# Altium schematic sheet JB LED DRIVE002.SchDoc
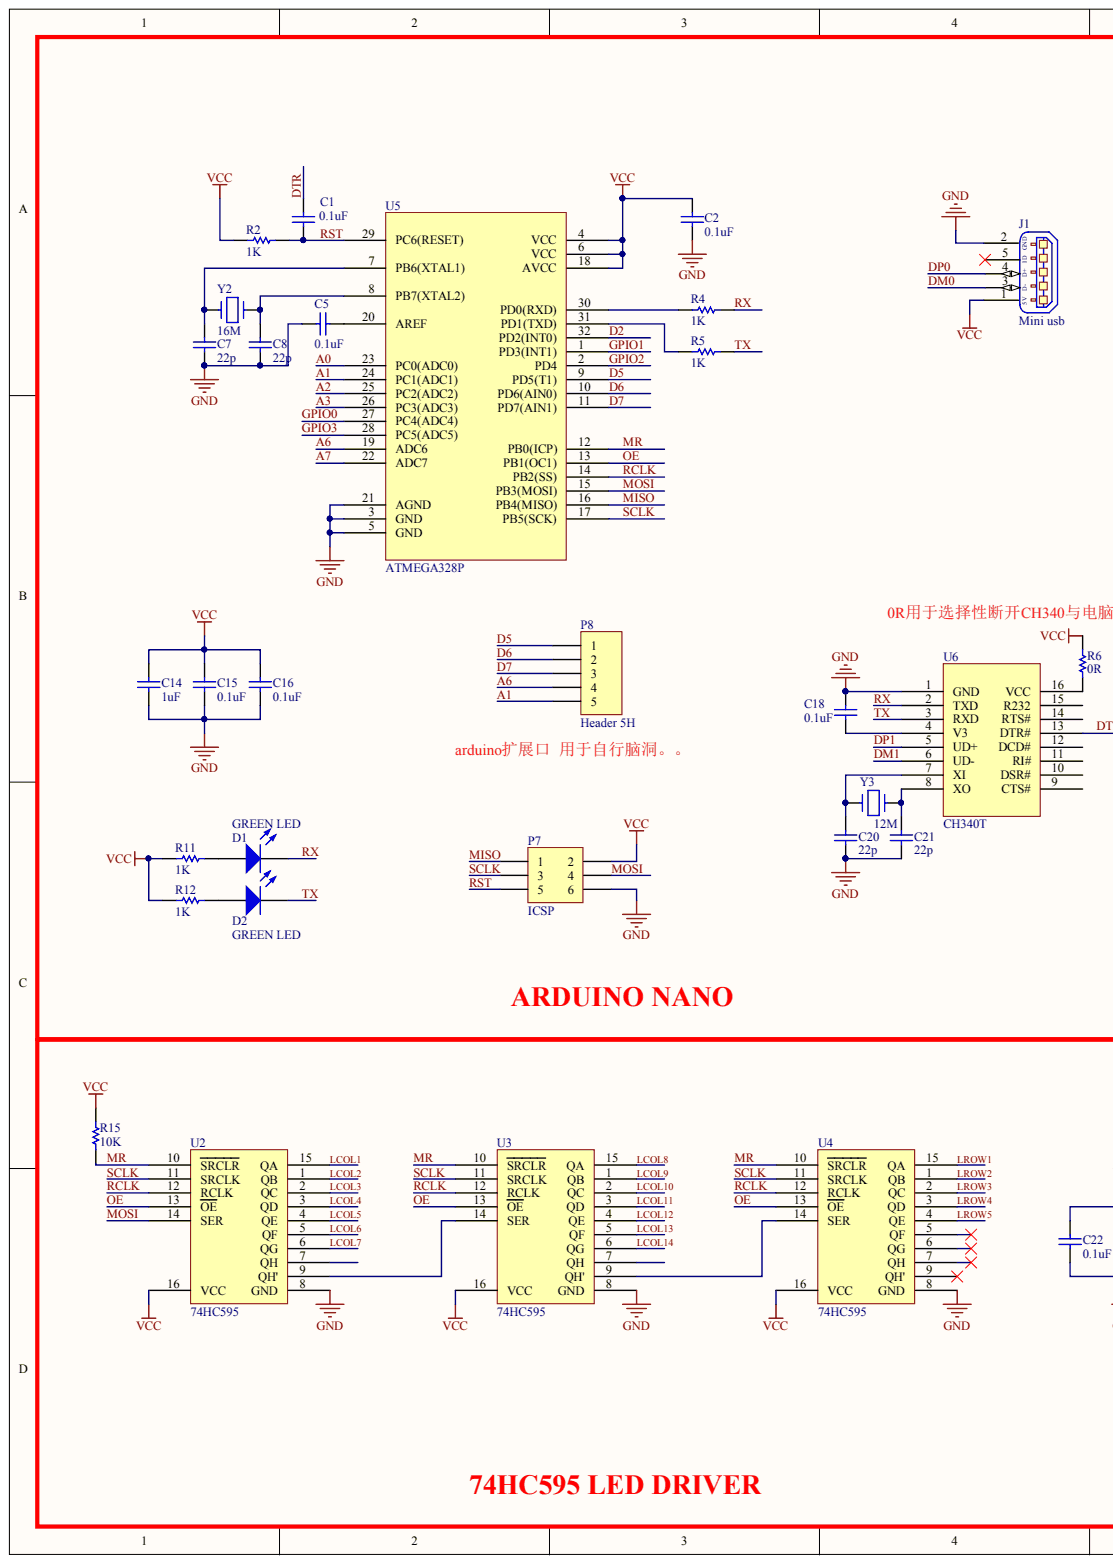
[diagram p0: JB LED DRIVE002.SchDoc, left]
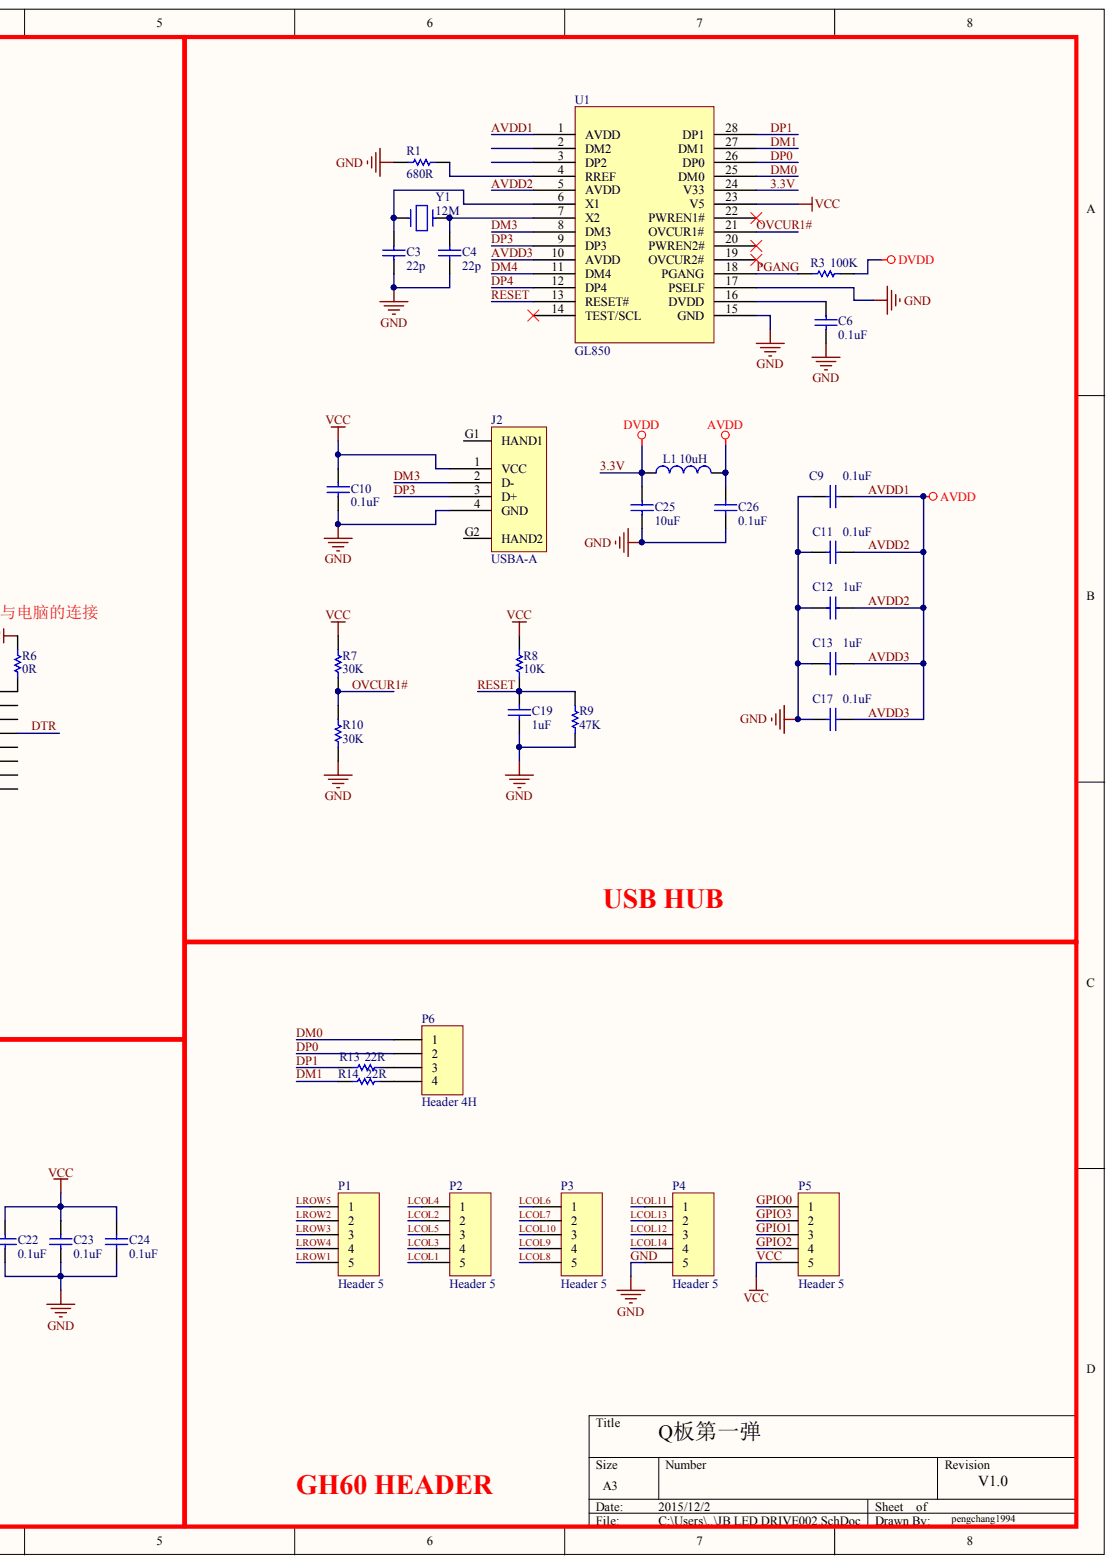
[diagram p1: JB LED DRIVE002.SchDoc, right]

## Parts
C1  0.1uF  [C0603]
C2  0.1uF  [C0603]
C3  22p  [C0603]
C4  22p  [C0603]
C5  0.1uF  [C0603]
C6  0.1uF  [C0603]
C7  22p  [C0603]
C8  22p  [C0603]
C9  0.1uF  [C0603]
C10  0.1uF  [C0603]
C11  0.1uF  [C0603]
C12  1uF  [C0603]
C13  1uF  [C0603]
C14  1uF  [C0603]
C15  0.1uF  [C0603]
C16  0.1uF  [C0603]
C17  0.1uF  [C0603]
C18  0.1uF  [C0603]
C19  1uF  [C0603]
C20  22p  [C0603]
C21  22p  [C0603]
C22  0.1uF  [C0603]
C23  0.1uF  [C0603]
C24  0.1uF  [C0603]
C25  10uF  [C0603]
C26  0.1uF  [C0603]
D1  GREEN LED  [D0805]
D2  GREEN LED  [D0805]
J1  Mini usb  [mini usb]
J2  USBA-A  [USB-A]
L1  10uH  [L0603]
P1  Header 5  [HEADER5-1]
P2  Header 5  [HEADER5-1]
P3  Header 5  [HEADER5-1]
P4  Header 5  [HEADER5-1]
P5  Header 5  [HEADER5-1]
P6  Header 4H  [HEADER4]
P7  ICSP  [HDR2X3]
P8  Header 5H  [header 5-3]
R1  680R  [R0603]
R2  1K  [R0603]
R3  100K  [R0603]
R4  1K  [R0603]
R5  1K  [R0603]
R6  0R  [R0603]
R7  30K  [R0603]
R8  10K  [R0603]
R9  47K  [R0603]
R10  30K  [R0603]
R11  1K  [R0603]
R12  1K  [R0603]
R13  22R  [R0603]
R14  22R  [R0603]
R15  10K  [R0603]
U1  GL850  [sop28]
U2  74HC595  [sop16-small]
U3  74HC595  [sop16-small]
U4  74HC595  [sop16-small]
U5  ATMEGA328P  [TQFP32]
U6  CH340T  [sop16-small]
Y1  12M  [XTAL]
Y2  16M  [XTAL]
Y3  12M  [XTAL]

## Nets
3.3V: C25.1 L1.1 R3.1 U1.24(V33)
A0: U5.23(PC0(ADC0))
A1: P8.5 U5.24(PC1(ADC1))
A2: U5.25(PC2(ADC2))
A3: U5.26(PC3(ADC3))
A6: P8.4 U5.19(ADC6)
A7: U5.22(ADC7)
AVDD1: C9.1 C11.1 C12.1 C13.1 C17.1 C26.1 L1.2 U1.1(AVDD) U1.5(AVDD) U1.10(AVDD)
D2: U5.32(PD2(INT0))
D5: P8.1 U5.9(PD5(T1))
D6: P8.2 U5.10(PD6(AIN0))
D7: P8.3 U5.11(PD7(AIN1))
DM0: J1.3(D-) P6.1 U1.25(DM0)
DM1: R14.2 U1.27(DM1) U6.6(UD-)
DM3: J2.2(D-) U1.8(DM3)
DM4: U1.11(DM4)
DP0: J1.4(D+) P6.2 U1.26(DP0)
DP1: R13.2 U1.28(DP1) U6.5(UD+)
DP3: J2.3(D+) U1.9(DP3)
DP4: U1.12(DP4)
DTR: C1.1 U6.13(DTR#)
GND: C2.2 C3.2 C4.2 C5.1 C6.2 C7.2 C8.2 C9.2 C10.2 C11.2 C12.2 C13.2 C14.2 C15.2 C16.2 C17.2 C18.1 C19.2 C20.2 C21.2 C22.2 C23.2 C24.2 C25.2 C26.2 J1.2 J2.4(GND) P4.5 P7.6 R1.2 R9.2 R10.2 U1.15(GND) U1.17(PSELF) U2.8(GND) U3.8(GND) U4.8(GND) U5.3(GND) U5.5(GND) U5.21(AGND) U6.1(GND)
GPIO0: P5.1 U5.27(PC4(ADC4))
GPIO1: P5.3 U5.1(PD3(INT1))
GPIO2: P5.4 U5.2(PD4)
GPIO3: P5.2 U5.28(PC5(ADC5))
LCOL1: P2.5 U2.15(QA)
LCOL2: P2.2 U2.1(QB)
LCOL3: P2.4 U2.2(QC)
LCOL4: P2.1 U2.3(QD)
LCOL5: P2.3 U2.4(QE)
LCOL6: P3.1 U2.5(QF)
LCOL7: P3.2 U2.6(QG)
LCOL8: P3.5 U3.15(QA)
LCOL9: P3.4 U3.1(QB)
LCOL10: P3.3 U3.2(QC)
LCOL11: P4.1 U3.3(QD)
LCOL12: P4.3 U3.4(QE)
LCOL13: P4.2 U3.5(QF)
LCOL14: P4.4 U3.6(QG)
LROW1: P1.5 U4.15(QA)
LROW2: P1.2 U4.1(QB)
LROW3: P1.3 U4.2(QC)
LROW4: P1.4 U4.3(QD)
LROW5: P1.1 U4.4(QE)
MISO: P7.1 U5.16(PB4(MISO))
MOSI: P7.4 U2.14(SER) U5.15(PB3(MOSI))
MR: R15.2 U2.10(SRCLR) U3.10(SRCLR) U4.10(SRCLR) U5.12(PB0(ICP))
OE: U2.13(OE) U3.13(OE) U4.13(OE) U5.13(PB1(OC1))
OVCUR1#: R7.2 R10.1 U1.21(OVCUR1#)
PGANG: R3.2 U1.18(PGANG)
RCLK: U2.12(RCLK) U3.12(RCLK) U4.12(RCLK) U5.14(PB2(SS))
RESET: C19.1 R8.2 R9.1 U1.13(RESET#)
RST: C1.2 P7.5 R2.1 U5.29(PC6(RESET))
RX: D1.2 R4.1 U6.2(TXD)
SCLK: P7.3 U2.11(SRCLK) U3.11(SRCLK) U4.11(SRCLK) U5.17(PB5(SCK))
TX: D2.2 R5.1 U6.3(RXD)
VCC: C2.1 C10.1 C14.1 C15.1 C16.1 C22.1 C23.1 C24.1 J1.1(5V) J2.1(VCC) P5.5 P7.2 R2.2 R6.1 R7.1 R8.1 R11.2 R12.2 R15.1 U1.23(V5) U2.16(VCC) U3.16(VCC) U4.16(VCC) U5.4(VCC) U5.6(VCC) U5.18(AVCC)
NetC3_1: C3.1 U1.6(X1) Y1.1
NetC4_1: C4.1 U1.7(X2) Y1.2
NetC5_2: C5.2 U5.20(AREF)
NetC6_1: C6.1 U1.16(DVDD)
NetC7_1: C7.1 U5.7(PB6(XTAL1)) Y2.1
NetC8_1: C8.1 U5.8(PB7(XTAL2)) Y2.2
NetC18_2: C18.2 U6.4(V3)
NetC20_1: C20.1 U6.7(XI) Y3.1
NetC21_1: C21.1 U6.8(XO) Y3.2
NetD1_1: D1.1 R11.1
NetD2_1: D2.1 R12.1
NetP6_3: P6.3 R13.1
NetP6_4: P6.4 R14.1
NetR1_1: R1.1 U1.4(RREF)
NetR4_2: R4.2 U5.30(PD0(RXD))
NetR5_2: R5.2 U5.31(PD1(TXD))
NetR6_2: R6.2 U6.16(VCC)
NetU2_9: U2.9(QH') U3.14(SER)
NetU3_9: U3.9(QH') U4.14(SER)

Not connected: J1 5(ID); J2 G1(HAND1) G2(HAND2); U1 2(DM2) 3(DP2) 14(TEST/SCL) 19(OVCUR2#) 20(PWREN2#) 22(PWREN1#); U2 7(QH); U3 7(QH); U4 5(QF) 6(QG) 7(QH) 9(QH'); U6 9(CTS#) 10(DSR#) 11(RI#) 12(DCD#) 14(RTS#) 15(R232)

## Library data of the parts
C1-C26 = Cap: Capacitor; Component Kind: Standard; LatestRevisionDate: 17-Jul-2002; LatestRevisionNote: Re-released for DXP Platform.; Library Name: Miscellaneous Devices.IntLib; PackageDescription: Radial Cap, Thru-Hole; 2 Leads; 0.3 in Pin Spacing; PackageReference: RAD-0.3; Published: 8-Jun-2000; Publisher: Altium Limited
  C19 C22-C24: Signal Integrity: Cap; Simulation: CAP
D1 D2 = LED0: Typical INFRARED GaAs LED; Component Kind: Standard; LatestRevisionDate: 08-Jul-2005; LatestRevisionNote: Mech Layer 1 removed.; Library Name: Miscellaneous Devices.IntLib; PackageDescription: LED; 2 Leads; PackageReference: LED-0; Published: 8-Jun-2000; Simulation: LED0; Publisher: Altium Limited
J1 = USB micro: Component Kind: Standard; Library Name: Op Amp.SCHLIB
J2 = USBA: Component Kind: Standard; Library Name: Miscellaneous Devices.SCHLIB
L1 = Inductor: Code_IEC: 1005; Code_JEITA: 0402; Component Kind: Standard; Description: Inductor; LatestRevisionDate: 29-May-2009; LatestRevisionNote: IPC-7351 Footprint Added.; Library Name: Miscellaneous Devices.IntLib; PackageDescription: Chip Inductor; PackageReference: 0402-A; Published: 8-Jun-2000; Signal Integrity: Inductor; Simulation: INDUCTOR; Publisher: Altium Limited
P1-P5 = Header 5: Header, 5-Pin; Component Kind: Standard; LatestRevisionDate: 17-Jul-2002; LatestRevisionNote: Re-released for DXP Platform.; Library Name: Miscellaneous Connectors.IntLib; Signal Integrity: Connector; Publisher: Altium Limited
P6 = Header 4H: Header, 4-Pin, Right Angle; Component Kind: Standard; LatestRevisionDate: 17-Jul-2002; LatestRevisionNote: Re-released for DXP Platform.; Library Name: Miscellaneous Connectors.IntLib; Publisher: Altium Limited
P7 = Header 3X2: Header, 3-Pin, Dual row; Component Kind: Standard; LatestRevisionDate: 17-Jul-2002; LatestRevisionNote: Re-released for DXP Platform.; Library Name: Miscellaneous Connectors.IntLib; Signal Integrity: Connector; Publisher: Altium Limited
P8 = Header 5H: Header, 5-Pin, Right Angle; Component Kind: Standard; LatestRevisionDate: 17-Jul-2002; LatestRevisionNote: Re-released for DXP Platform.; Library Name: Miscellaneous Connectors.IntLib; Signal Integrity: Connector; Publisher: Altium Limited
R1-R15 = Res1: Resistor; Component Kind: Standard; LatestRevisionDate: 17-Jul-2002; LatestRevisionNote: Re-released for DXP Platform.; Library Name: Miscellaneous Devices.IntLib; PackageDescription: Axial Device, Thru-Hole; 2 Leads; 0.3 in Pin Spacing; PackageReference: AXIAL-0.3; Published: 8-Jun-2000; Publisher: Altium Limited
  R6 R15: Signal Integrity: Res; Simulation: RESISTOR
Y1-Y3 = CRYSTAL: Component Kind: Standard; Description: Crystal; Library Name: Miscellaneous Devices.SCHLIB
U1 = GL850: Component Kind: Standard; Library Name: Miscellaneous Devices.SCHLIB
U2-U4 = 74HC595: Component Kind: Standard; Library Name: Miscellaneous Devices.SCHLIB
U5 = ATMEGA328P: Component Kind: Standard; Library Name: Miscellaneous Devices.SCHLIB
U6 = CH340T: Component Kind: Standard; Library Name: Miscellaneous Devices.SCHLIB
